# Altium schematic sheet Main_schematics.SchDoc
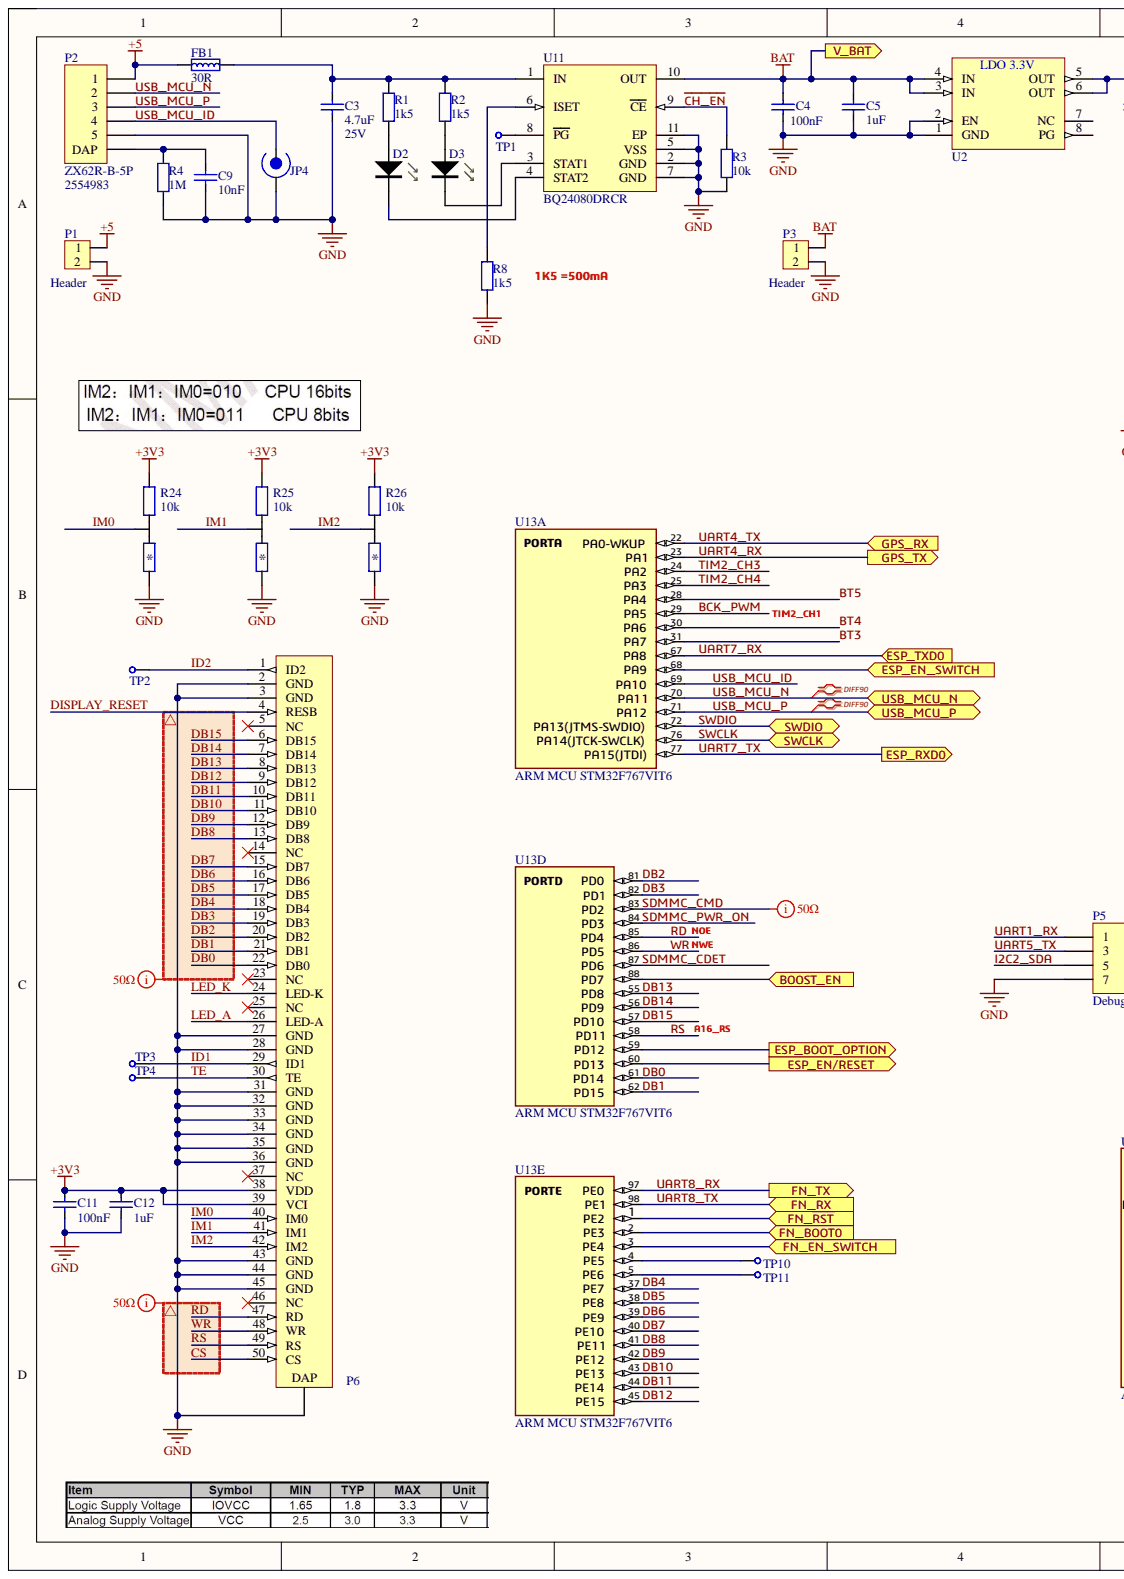
[diagram p0: Main_schematics.SchDoc, left]
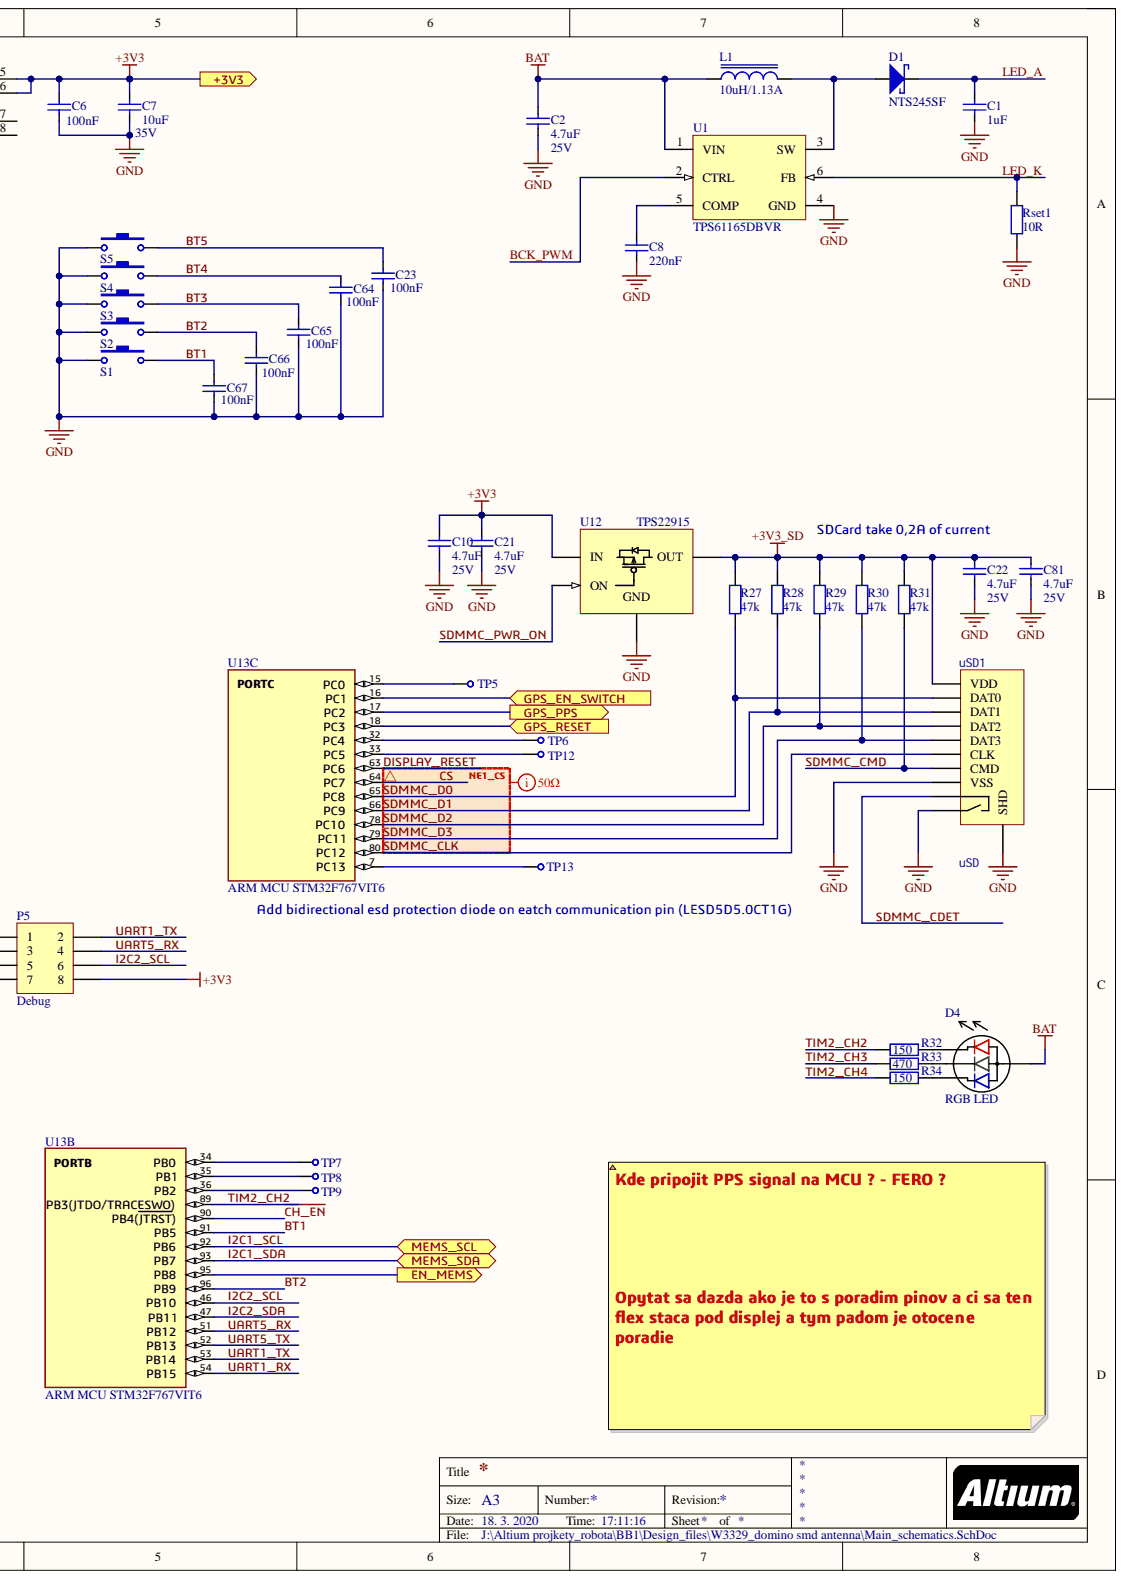
[diagram p1: Main_schematics.SchDoc, right]

## Parts
C1  1uF  [CAPACITOR_0603_(1608)]
C2  4.7uF  Voltage: 25V  [CAPACITOR_0603_(1608)]
C3  4.7uF  Voltage: 25V  [CAPACITOR_0603_(1608)]
C4  100nF  [CAPACITOR_0402_(1005)]
C5  1uF  [CAPACITOR_0603_(1608)]
C6  100nF  [CAPACITOR_0402_(1005)]
C7  10uF  Voltage Rating: 35V  [CAPACITOR_0805_(2012)]
C8  220nF  [CAPACITOR_0603_(1608)]
C9  10nF  [CAPACITOR_0603_(1608)]
C10  4.7uF  Voltage: 25V  [CAPACITOR_0603_(1608)]
C11  100nF  [CAPACITOR_0402_(1005)]
C12  1uF  [CAPACITOR_0603_(1608)]
C21  4.7uF  Voltage: 25V  [CAPACITOR_0603_(1608)]
C22  4.7uF  Voltage: 25V  [CAPACITOR_0603_(1608)]
C23  100nF  [CAPACITOR_0402_(1005)]
C64  100nF  [CAPACITOR_0402_(1005)]
C65  100nF  [CAPACITOR_0402_(1005)]
C66  100nF  [CAPACITOR_0402_(1005)]
C67  100nF  [CAPACITOR_0402_(1005)]
C81  4.7uF  Voltage: 25V  [CAPACITOR_0603_(1608)]
D1  NTS245SF  [SOD-123FL]
D2  LED GREEN  [LED_0805_GREEN]  (value not drawn)
D3  LED GREEN  [LED_0805_GREEN]  (value not drawn)
D4  RGB LED  [RGB SMD]
FB1  30R  [FERRITE BEAD_0603_(1608)]
JP4  Jumper  [JUMPER OFF SMD]  (value not drawn)  (no BOM)
L1  10uH/1.13A  [Power Inductor (SMD)]
P1  Header  [Header 2]
P2  ZX62R-B-5P  Supplier Part Number 1: 2554983  [ZX62R-B-5P]
P3  Header  [Header 2]
P5  Debug  [HDR2X4]
P6  Connector  [MOLEX 51338-0574]  (value not drawn)
R1  1k5  [RESISTOR_0603_(1608)]
R2  1k5  [RESISTOR_0603_(1608)]
R3  10k  [RESISTOR_0603_(1608)]
R4  1M  [RESISTOR_0603_(1608)]
R8  1k5  [RESISTOR_0603_(1608)]
R24  10k  [R603-3pad]
R25  10k  [R603-3pad]
R26  10k  [R603-3pad]
R27  47k  [RESISTOR_0603_(1608)]
R28  47k  [RESISTOR_0603_(1608)]
R29  47k  [RESISTOR_0603_(1608)]
R30  47k  [RESISTOR_0603_(1608)]
R31  47k  [RESISTOR_0603_(1608)]
R32  150  [RESISTOR_0603_(1608)]
R33  470  [RESISTOR_0603_(1608)]
R34  150  [RESISTOR_0603_(1608)]
Rset1  10R  [RESISTOR_0603_(1608)]
S1  DTSM-32S-B -  Tactile Switch  [DTSM-32S-B]  (value not drawn)
S2  DTSM-32S-B -  Tactile Switch  [DTSM-32S-B]  (value not drawn)
S3  DTSM-32S-B -  Tactile Switch  [DTSM-32S-B]  (value not drawn)
S4  DTSM-32S-B -  Tactile Switch  [DTSM-32S-B]  (value not drawn)
S5  DTSM-32S-B -  Tactile Switch  [DTSM-32S-B]  (value not drawn)
TP1  test point  [TP]  (value not drawn)  (no BOM)
TP2  test point  [TP]  (value not drawn)  (no BOM)
TP3  test point  [TP]  (value not drawn)  (no BOM)
TP4  test point  [TP]  (value not drawn)  (no BOM)
TP5  test point  [TP]  (value not drawn)  (no BOM)
TP6  test point  [TP]  (value not drawn)  (no BOM)
TP7  test point  [TP]  (value not drawn)  (no BOM)
TP8  test point  [TP]  (value not drawn)  (no BOM)
TP9  test point  [TP]  (value not drawn)  (no BOM)
TP10  test point  [TP]  (value not drawn)  (no BOM)
TP11  test point  [TP]  (value not drawn)  (no BOM)
TP12  test point  [TP]  (value not drawn)  (no BOM)
TP13  test point  [TP]  (value not drawn)  (no BOM)
U1  TPS61165DBVR  [TI-DBV6_L]
U2  LDO_3V3  [SOIC8]  (value not drawn)
U11  BQ24080DRCR  [DRC0010J_V]
U12  TPS22915  [DSBGA4_0.4mmpitch]
U13  ARM MCU STM32F767VIT6  Manufacturer Part Number: STM32F767VIT6  [STM-LQFP100_N]  (parts A,B,C,D,E)
uSD1  uSD  [uSD]

## Ports
+3V3, BOOST_EN, EN_MEMS, ESP_BOOT_OPTION, ESP_EN/RESET, ESP_EN_SWITCH, ESP_RXD0, ESP_TXD0, FN_BOOT0, FN_EN_SWITCH, FN_RX, FN_TX, FN_~{RST}, GPS_EN_SWITCH, GPS_PPS, GPS_RESET, GPS_RX, GPS_TX, MEMS_SCL, MEMS_SDA, SWCLK, SWDIO, USB_MCU_N, USB_MCU_P, V_BAT

## Nets
+3V3_SD: C22.1 C81.1 R27.2 R28.2 R29.2 R30.2 R31.2 U12.A1(OUT) uSD1.4(VDD)
+5: FB1.2 P1.1 P2.1
GND: C1.2 C2.2 C3.2 C4.2 C5.2 C6.2 C7.2 C8.1 C9.1 C10.2 C11.1 C12.1 C21.2 C22.2 C23.2 C64.2 C65.2 C66.2 C67.2 C81.2 JP4.1 P1.2 P2.5 P3.2 P5.7 P6.0 P6.2(GND) P6.3(GND) P6.27(GND) P6.28(GND) P6.31(GND) P6.32(GND) P6.33(GND) P6.34(GND) P6.35(GND) P6.36(GND) P6.43(GND) P6.44(GND) P6.45(GND) R3.1 R4.1 R8.1 R24.1 R25.1 R26.1 Rset1.1 S1.2 S2.2 S3.2 S4.2 S5.2 U1.4(GND) U2.1(GND) U2.2(EN) U11.2(GND) U11.5(VSS) U11.7(GND) U11.11(EP) U12.B1 uSD1.0(SHD) uSD1.6(VSS) uSD1.10
+3V3: C6.1 C7.1 C10.1 C11.2 C12.2 C21.1 P5.8 P6.38(VDD) P6.39(VCI) R24.3 R25.3 R26.3 U2.5(OUT) U2.6(OUT) U12.A2(IN) ; port +3V3
BAT: C2.1 C4.1 C5.1 D4.1 L1.1 P3.1 U1.1(VIN) U2.3(IN) U2.4(IN) U11.10(OUT) ; port V_BAT
BCK_PWM: U1.2(CTRL) U13.29(PA5)
BOOST_EN: U13.88(PD7) ; port BOOST_EN
BT1: C67.1 S1.1 U13.91(PB5)
BT2: C66.1 S2.1 U13.96(PB9)
BT3: C65.1 S3.1 U13.31(PA7)
BT4: C64.1 S4.1 U13.30(PA6)
BT5: C23.1 S5.1 U13.28(PA4)
~{CH_EN}: R3.2 U11.9(CE) U13.90(PB4(JTRST))
CS: P6.50(CS) U13.64(PC7)
DB0: P6.22(DB0) U13.61(PD14)
DB1: P6.21(DB1) U13.62(PD15)
DB2: P6.20(DB2) U13.81(PD0)
DB3: P6.19(DB3) U13.82(PD1)
DB4: P6.18(DB4) U13.37(PE7)
DB5: P6.17(DB5) U13.38(PE8)
DB6: P6.16(DB6) U13.39(PE9)
DB7: P6.15(DB7) U13.40(PE10)
DB8: P6.13(DB8) U13.41(PE11)
DB9: P6.12(DB9) U13.42(PE12)
DB10: P6.11(DB10) U13.43(PE13)
DB11: P6.10(DB11) U13.44(PE14)
DB12: P6.9(DB12) U13.45(PE15)
DB13: P6.8(DB13) U13.55(PD8)
DB14: P6.7(DB14) U13.56(PD9)
DB15: P6.6(DB15) U13.57(PD10)
DISPLAY_RESET: P6.4(RESB) U13.63(PC6)
EN_MEMS: U13.95(PB8) ; port EN_MEMS
ESP_BOOT_OPTION: U13.59(PD12) ; port ESP_BOOT_OPTION
ESP_EN/RESET: U13.60(PD13) ; port ESP_EN/RESET
ESP_EN_SWITCH: U13.68(PA9) ; port ESP_EN_SWITCH
FN_BOOT0: U13.2(PE3) ; port FN_BOOT0
FN_EN_SWITCH: U13.3(PE4) ; port FN_EN_SWITCH
FN_~{RST}: U13.1(PE2) ; port FN_~{RST}
GPS_EN_SWITCH: U13.16(PC1) ; port GPS_EN_SWITCH
GPS_PPS: U13.17(PC2) ; port GPS_PPS
GPS_RESET: U13.18(PC3) ; port GPS_RESET
I2C1_SCL: U13.92(PB6) ; port MEMS_SCL
I2C1_SDA: U13.93(PB7) ; port MEMS_SDA
I2C2_SCL: P5.6 U13.46(PB10)
I2C2_SDA: P5.5 U13.47(PB11)
ID1: P6.29(ID1) TP3.1
ID2: P6.1(ID2) TP2.1
IM0: P6.40(IM0) R24.2
IM1: P6.41(IM1) R25.2
IM2: P6.42(IM2) R26.2
LED_A: C1.1 D1.2 P6.26(LED-A)
LED_K: P6.24(LED-K) Rset1.2 U1.6(FB)
RD: P6.47(RD) U13.85(PD4)
RS: P6.49(RS) U13.58(PD11)
SDMMC_CDET: U13.87(PD6) uSD1.9
SDMMC_CLK: U13.80(PC12) uSD1.5(CLK)
SDMMC_CMD: R31.1 U13.83(PD2) uSD1.3(CMD)
SDMMC_D0: R27.1 U13.65(PC8) uSD1.7(DAT0)
SDMMC_D1: R28.1 U13.66(PC9) uSD1.8(DAT1)
SDMMC_D2: R29.1 U13.78(PC10) uSD1.1(DAT2)
SDMMC_D3: R30.1 U13.79(PC11) uSD1.2(DAT3)
SDMMC_PWR_ON: U12.B2(ON) U13.84(PD3)
SWCLK: U13.76(PA14(JTCK-SWCLK)) ; port SWCLK
SWDIO: U13.72(PA13(JTMS-SWDIO)) ; port SWDIO
TE: P6.30(TE) TP4.1
TIM2_CH2: R32.2 U13.89(PB3(JTDO/TRACESWO))
TIM2_CH3: R33.2 U13.24(PA2)
TIM2_CH4: R34.2 U13.25(PA3)
UART1_RX: P5.1 U13.54(PB15)
UART1_TX: P5.2 U13.53(PB14)
UART4_RX: U13.23(PA1) ; port GPS_TX
UART4_TX: U13.22(PA0-WKUP) ; port GPS_RX
UART5_RX: P5.4 U13.51(PB12)
UART5_TX: P5.3 U13.52(PB13)
UART7_RX: U13.67(PA8) ; port ESP_TXD0
UART7_TX: U13.77(PA15(JTDI)) ; port ESP_RXD0
UART8_RX: U13.97(PE0) ; port FN_TX
UART8_TX: U13.98(PE1) ; port FN_RX
USB_MCU_ID: JP4.2 P2.4 U13.69(PA10)
USB_MCU_N: P2.2 U13.70(PA11) ; port USB_MCU_N
USB_MCU_P: P2.3 U13.71(PA12) ; port USB_MCU_P
WR: P6.48(WR) U13.86(PD5)
NetC3_1: C3.1 FB1.1 R1.2 R2.2 U11.1(IN)
NetC8_2: C8.2 U1.5(COMP)
NetC9_2: C9.2 P2.0(DAP) R4.2
NetD1_1: D1.1 L1.2 U1.3(SW)
NetD2_A: D2.A R1.1
NetD2_K: D2.K U11.4(STAT2)
NetD3_A: D3.A R2.1
NetD3_K: D3.K U11.3(STAT1)
NetD4_2: D4.2 R34.1
NetD4_3: D4.3 R32.1
NetD4_4: D4.4 R33.1
NetR8_2: R8.2 U11.6(ISET)
NetTP1_1: TP1.1 U11.8(PG)
NetTP5_1: TP5.1 U13.15(PC0)
NetTP6_1: TP6.1 U13.32(PC4)
NetTP7_1: TP7.1 U13.34(PB0)
NetTP8_1: TP8.1 U13.35(PB1)
NetTP9_1: TP9.1 U13.36(PB2)
NetTP10_1: TP10.1 U13.4(PE5)
NetTP11_1: TP11.1 U13.5(PE6)
NetTP12_1: TP12.1 U13.33(PC5)
NetTP13_1: TP13.1 U13.7(PC13)

Not connected: P6 5(NC) 14(NC) 23(NC) 25(NC) 37(NC) 46(NC); U2 7(NC) 8(PG)

## Library data of the parts
C1 C4-C6 C8 C9 C11 C12 C23 C64-C67 = CAPACITOR: ESR: 0.28R; Voltage Rating: 10V; Component Kind: Standard; Description: CAPACITOR; Library Name: RLCFB.SchLib
  C1 C5 C12: Capacitance: 1uF
  C4 C6 C11 C23 C64-C67: Capacitance: 100nF
  C8: Capacitance: 220nF
  C9: Capacitance: 10nF
C2 C3 C10 C21 C22 C81 = CAPACITOR: Manufacturer 1: Murata; Manufacturer Part Number 1: GRM188R61E475KE11D; Dielectric: X5R; ESR: 0.28R; Material: Ceramic; Tolerance: 10%; Capacitance: 4.7uF; Case Code (Imperial): 0603; Case Code (Metric): 1608; Case/Package: 0603; Component Kind: Standard; ComponentLink1Description: https://datasheet.ciiva.com/46444/1769893-46444982.pdf?src-supplier=Element14; ComponentLink1URL: https://datasheet.ciiva.com/46444/1769893-46444982.pdf?src-supplier=Element14; ComponentLink2Description: https://datasheet.ciiva.com/46444/1769893-46444983.pdf?src-supplier=Element14; ComponentLink2URL: https://datasheet.ciiva.com/46444/1769893-46444983.pdf?src-supplier=Element14; ComponentLink3Description: https://datasheet.ciiva.com/14891/partnumbering-e-01-14891102.pdf?src-supplier=Digi-Key; ComponentLink3URL: https://datasheet.ciiva.com/14891/partnumbering-e-01-14891102.pdf?src-supplier=Digi-Key; ComponentLink4Description: https://datasheet.ciiva.com/14891/partnumbering-e-01-14891103.pdf?src-supplier=Digi-Key; ComponentLink4URL: https://datasheet.ciiva.com/14891/partnumbering-e-01-14891103.pdf?src-supplier=Digi-Key; Depth: 0.8mm; Description: CAPACITOR; Height: 0.8mm; Lead Free: Lead Free; Length: 1.6mm; Max Operating Temperature: 85°C; Min Operating Temperature: -55°C; Mount: Surface Mount; Package Quantity: 4000; Packaging: Cut Tape; Pricing 1: 10=0,35700, 100=100,00000, 500=0,15900, 1000=0,12800, 2000=0,10200 (CHF); REACH SVHC: No SVHC; RoHS: Yes; Stock 1: 95119; Supplier 1: Farnell; Supplier Part Number 1: 2426959; Thickness: 0.031inch; Voltage Rating: 25V; Voltage Rating (DC): 25V; Width: 0.031inch; Library Name: RLCFB.SchLib
C7 = CAPACITOR: Manufacturer 1: Murata; Manufacturer Part Number 1: GRM21BR6YA106KE43L; Dielectric: X5R; Tolerance: 10%; Capacitance: 10uF; Case Code (Imperial): 0805; Case Code (Metric): 2012; Case/Package: 0805; Component Kind: Standard; ComponentLink1Description: http://www.farnell.com/datasheets/2079187.pdf?src-supplier=Farnell; ComponentLink1URL: http://www.farnell.com/datasheets/2079187.pdf?src-supplier=Farnell; ComponentLink2Description: http://www.mouser.com/ds/2/281/c02e-2905.pdf?src-supplier=Mouser; ComponentLink2URL: http://www.mouser.com/ds/2/281/c02e-2905.pdf?src-supplier=Mouser; ComponentLink3Description: http://search.murata.co.jp/Ceramy/image/img/A01X/G101/ENG/GRM21BR6YA106KE43-01.pdf?src-supplier=Digi-Key; ComponentLink3URL: http://search.murata.co.jp/Ceramy/image/img/A01X/G101/ENG/GRM21BR6YA106KE43-01.pdf?src-supplier=Digi-Key; ComponentLink4Description: http://search.murata.co.jp/Ceramy/image/img/A01X/partnumbering_e_01.pdf?src-supplier=Digi-Key; ComponentLink4URL: http://search.murata.co.jp/Ceramy/image/img/A01X/partnumbering_e_01.pdf?src-supplier=Digi-Key; ComponentLink5Description: http://www.farnell.com/datasheets/2079187.pdf?src-supplier=Newark; ComponentLink5URL: http://www.farnell.com/datasheets/2079187.pdf?src-supplier=Newark; ComponentLink6Description: http://www.farnell.com/datasheets/2079187.pdf?src-supplier=Element14; ComponentLink6URL: http://www.farnell.com/datasheets/2079187.pdf?src-supplier=Element14; Description: CAPACITOR; Height: 1.25mm; Lead Free: Lead Free; Length: 0.079inch; Max Operating Temperature: 85°C; Min Operating Temperature: -55°C; Mount: Surface Mount; Package Quantity: 3000; Packaging: Tape and Reel; Pricing 1: 5=0,38700, 50=0,21000, 250=0,18000, 500=0,11500, 1500=0,10500, 3000=0,09410, 15000=0,09070 (EUR); REACH SVHC: No SVHC; RoHS: Yes; Stock 1: 109244; Supplier 1: Farnell; Supplier Part Number 1: 2611943; Termination: SMD/SMT; Thickness: 0.057inch; Voltage Rating (DC): 35V; Weight: 0.000335oz; Width: 0.049inch; Library Name: RLCFB.SchLib
D1 = D_NTS245SF: Very Low Forward Voltage  Trench-based Schottky  Rectifier in SOD−123FL; Manufacturer: ON Semiconductor; Manufacturer Part Number: NTS245SFT1G; Average Rectified Current: 2A; Element Configuration: Single; Forward Voltage: 630mV; Max Forward Surge Current (Ifsm): 50A; Max Repetitive Reverse Voltage (Vrrm): 45V; Peak Reverse Current: 90uA; Case/Package: SOD; Component Kind: Standard; ComponentLink1Description: http://www.mouser.com/ds/2/308/NTS245SF-D-532018.pdf; ComponentLink1URL: http://www.mouser.com/ds/2/308/NTS245SF-D-532018.pdf; ComponentLink2Description: http://uk.rs-online.com/webdocs/13b8/0900766b813b8bbc.pdf; ComponentLink2URL: http://uk.rs-online.com/webdocs/13b8/0900766b813b8bbc.pdf; ComponentLink3Description: http://www.farnell.com/datasheets/1900863.pdf; ComponentLink3URL: http://www.farnell.com/datasheets/1900863.pdf; ComponentLink4Description: http://www.onsemi.com/pub/Collateral/NTS245SF-D.PDF; ComponentLink4URL: http://www.onsemi.com/pub/Collateral/NTS245SF-D.PDF; ComponentLink5Description: http://www.onsemi.com/pub_link/Collateral/NTS245SF-D.PDF; ComponentLink5URL: http://www.onsemi.com/pub_link/Collateral/NTS245SF-D.PDF; Forward Current: 2A; Height: 0.88mm; Lead Free: Lead Free; Length: 2.9mm; Max Operating Temperature: 150°C; Max Reverse Voltage (DC): 45V; Min Operating Temperature: -65°C; Mount: Surface Mount; Number of Pins: 2; Package Quantity: 3000; Packaging: Tape and Reel; Pricing 1: 5=0.276, 25=0.267, 100=0.108, 250=0.101, 500=0.0772, 1000=0.0533, 5000=0.0433 (EUR); REACH SVHC: No SVHC; Reverse Voltage (DC): 45V; Stock 1: 46422; Supplier 1: Farnell; Supplier Part Number 1: 2473418; Width: 1.8mm; Library Name: LVDS_to_LVTTL.SchLib
D2 D3 = LED GREEN 0805: KP-2012LSGC -  LED, Low Power, Green, SMD, 0805, 2 mA, 1.9 V, 568 nm; Manufacturer 1: Kingbright; Forward Current: 2mA; Forward Voltage: 1.9V; Lens Style: Rectangular; Viewing Angle: 120°; Wavelength: 568nm; Case/Package: 120; Color: Green; Component Kind: Standard; ComponentLink1Description: http://www.farnell.com/datasheets/1854080.pdf?src-supplier=Farnell; ComponentLink1URL: http://www.farnell.com/datasheets/1854080.pdf?src-supplier=Farnell; ComponentLink2Description: http://www.farnell.com/datasheets/1854080.pdf?src-supplier=Element14; ComponentLink2URL: http://www.farnell.com/datasheets/1854080.pdf?src-supplier=Element14; Library Name: Source.SchLib; Manufacturer Part Number 1: KP-2012LSGC; Packaging: Cut Tape; Pricing 1: 5=0,11200, 10=0,10000, 25=0,08820, 50=0,08670, 100=0,08510, 150=0,08330, 200=0,08150, 250=0,07970 (EUR); REACH SVHC: No SVHC; RoHS: Yes; Stock 1: 19790; Supplier 1: Farnell; Supplier Part Number 1: 2463991
D4 = RGB LED: RGB LED kolmo na PCB; Component Kind: Standard; Library Name: BB1_SCH_Library.SchLib; Supplier 1: Farnell; Supplier Part Number 1: 2322115
FB1 = FERRITE_BEAD: DC Current Rating: 400mA; DC Resistance Max: 100R; Component Kind: Standard; Description: FERRITE_BEAD; Impedance@100MHz: 30R; Library Name: RLCFB.SchLib
JP4 = Jumper SMD: Description: Jumper; Library Name: Source.SchLib
L1 = IND_VLCF5020T: VLCF5020T-100M1R1-1 -  Power Inductor (SMD), 10 µH, 1.5 A, Wirewound, 1.13 A, VLCF Series, 5.3mm x 5mm x 2mm; Manufacturer: TDK; Core Material: Ferrite; Current Rating: 1.13A; DC Resistance (DCR): 237mR; Inductance: 10uh; Inductance Tolerance: 20%; RMS Current (Irms): 1.5A; Shielding: Shielded; Test Frequency: 100kHz; Case/Package: 2020; Component Kind: Standard; Composition: Wirewound; DC Current: 1.13A; Depth: 5.2mm; Height: 2mm; Height - Seated (Max): 0.079inch; Lead Free: Lead Free; Length: 0.197inch; Manufacturer Part Number: VLCF5020T-100M1R1-1; Max DC Current: 1.13A; Max Operating Temperature: 105°C; Military Standard: Not; Min Operating Temperature: -40°C; Mount: Surface Mount; Number of Pins: 2; Package Quantity: 500; Packaging: Tape and Reel; Pricing 1: 1=1.15, 10=0.755, 50=0.57, 100=0.51, 200=0.46, 500=0.409, 2500=0.359 (EUR); Radiation Hardening: No; REACH SVHC: No SVHC; Saturation Current: 1.13A; Stock 1: 1025; Supplier 1: Farnell; Supplier Part Number 1: 1670091; Termination: SMD/SMT; Tolerance: 20%; Width: 0.197inch; Library Name: LVDS_to_LVTTL.SchLib
P1 P3 = Header 2: 2.54mm header 2x1; Component Kind: Standard; Library Name: LVDS_to_LVTTL.SchLib
P2 = ZX62R-B-5P: micro USB reverse; Component Kind: Standard; Library Name: BB1_SCH_Library.SchLib; Supplier 1: Farnell
P5 = Header 4X2: Header, 4-Pin, Dual row; Component Kind: Standard; LatestRevisionDate: 17-Jul-2002; LatestRevisionNote: Re-released for DXP Platform.; Library Name: Miscellaneous Connectors.IntLib; Signal Integrity: Connector; Publisher: Altium Limited
P6 = Molex 0559090574: MOLEX         51338-0574            Stacking Board Connector, Receptacle, 0.4 mm, 50 Contacts, Surface Mount, Gold Plated Contacts; Current Rating: 300mA; Glow Wire Compliant: Non-compliant; Insulation Resistance: 100MR; Number of Circuits: 50; Pitch: 0.4mm; Voltage Rating (AC): 50V; Category: Headers and Wire Housings; Color: Black; Component Kind: Standard; ComponentLink1Description: https://datasheet.ciiva.com/1440/1887871-1440036.pdf?src-supplier=Element14; ComponentLink1URL: https://datasheet.ciiva.com/1440/1887871-1440036.pdf?src-supplier=Element14; Contact Material: Bronze; Contact Plating: Gold; ELV: Compliant; Flammability Rating: UL94 V-0; Gender: Receptacle; Halogen Free: Low Halogen; Housing Material: Thermoplastic; Library Name: Display converter.SchLib; Manufacturer 1: Molex; Manufacturer Part Number 1: 51338-0574; Material: Bronze; Mount: Surface Mount; Number of Contacts: 50; Number of Positions: 50; Number of Rows: 2; Orientation: Vertical; Package Quantity: 2500; Packaging: Tape and Reel; Pricing 1: 1=2,06000, 10=1,87200, 25=1,75760, 50=1,68120, 100=1,60480, 250=1,45192, 500=1,33730, 1000=1,14626 (USD); REACH SVHC: Unknown; RoHS: Yes; Stock 1: 7419; Supplier 1: Digi-Key; Supplier Part Number 1: WM3354CT-ND; Termination: SMD/SMT; Voltage Rating (DC): 50V
R1-R4 R8 R27-R34 Rset1 = RESISTOR: Power Rating: 500mW; Component Kind: Standard; Description: RESISTOR; Library Name: RLCFB.SchLib
  R1 R2 R8: Resistance: 1k5
  R3: Resistance: 10k
  R4: Resistance: 1M
  R27-R31: Resistance: 47k
  R32 R34: Resistance: 150
  R33: Resistance: 470
  Rset1: Resistance: 10R
R24-R26 = R_PULL: Pull up or pull down resistor; Component Kind: Standard; Library Name: BB1_SCH_Library.SchLib
S1-S5 = Switch tactile: DTSM-32S-B -  Tactile Switch, DTSM-3 Series, Top Actuated, Surface Mount, Rectangular Button, 320 gf; Manufacturer 1: Multicomp; Contact Resistance: 100mR; Current Rating: 50mA; Illumination Type: Illuminated; Operating Force: 3.14N; Throw Configuration: SPST; Voltage Rating (DC): 12V; Case/Package: SMD/SMT; Component Kind: Standard; ComponentLink1Description: https://datasheet.ciiva.com/1532/44707-1532733.pdf?src-supplier=Element14; ComponentLink1URL: https://datasheet.ciiva.com/1532/44707-1532733.pdf?src-supplier=Element14; ComponentLink2Description: https://datasheet.ciiva.com/1532/10424-1532734.pdf?src-supplier=Element14; ComponentLink2URL: https://datasheet.ciiva.com/1532/10424-1532734.pdf?src-supplier=Element14; ComponentLink3Description: https://datasheet.ciiva.com/22631/1662013-22631920.pdf?src-supplier=Farnell; ComponentLink3URL: https://datasheet.ciiva.com/22631/1662013-22631920.pdf?src-supplier=Farnell; Height: 5mm; Insulation Resistance: 100MR; Length: 6mm; Library Name: BB1_SCH_Library.SchLib; Manufacturer Part Number 1: DTSM-32S-B; Max Operating Temperature: 70°C; Min Operating Temperature: -25°C; Pricing 1: 15=0,18700, 150=0,15100, 500=0,10800, 1000=0,09630, 1500=0,08590, 5000=0,07220 (EUR); REACH SVHC: No SVHC; RoHS: Yes; Stock 1: 170226; Supplier 1: Farnell; Supplier Part Number 1: 9471898; Weight: 0.01lb; Width: 6mm
U1 = TPS61165DBVR: High Brightness White LED Driver, 3 to 18 V, -40 to 85 degC, 6-pin SOT23 (DBV6), Green (RoHS & no Sb/Br); Manufacturer: Texas Instruments; Dimming: TRUE; Iq(Typ)(mA): 1.5; Rating: Catalog; Switching Frequency(Max)(kHz): 1200; Topology: Boost; Vin(Max)(V): 18; Vin(Min)(V): 3; Code_JEDEC: MO-178; Component Kind: Standard; ComponentLink1Description: Manufacturer URL; ComponentLink1URL: http://www.ti.com/; ComponentLink2Description: Datasheet; ComponentLink2URL: http://www.ti.com/general/docs/lit/getliterature.tsp?genericPartNumber=TPS61165&fileType=pdf; ComponentLink3Description: Package Specification; ComponentLink3URL: http://www.ti.com/litv/pdf/mpds026h; DatasheetVersion: SLVS790B; Eco-Plan: Green (RoHS & no Sb/Br); Mounting Technology: Surface Mount; PackageDescription: 6-Pin Plastic Small Outline, Body 1.60 Ã— 2.90 mm, Pitch 0.95 mm; PackageReference: DBV6; PackageVersion: 4073253-5/K; PartNumber: TPS61165DBVR; SubFamily: White LED Driver; Supplier 6: Farnell; Supplier Part Number 6: 2764825; Synchronous Rectification: TRUE; Library Name: LVDS_to_LVTTL.SchLib
U2 = LDO_3V3: FAST TRANSIENT RESPONSE, 1-A LOW-DROPOUT VOLTAGE REGULATOR; Manufacturer: TEXAS INSTRUMENTS; Manufacturer Part Number: TPS76833QD; Component Kind: Standard; ComponentLink1Description: http://www.ti.com/lit/ds/symlink/tps76801.pdf; ComponentLink1URL: http://www.ti.com/lit/ds/symlink/tps76801.pdf; ComponentLink2Description: http://www.farnell.com/cad/1610971.zip; ComponentLink2URL: http://www.farnell.com/cad/1610971.zip; Pricing 1: 1=2,17, 10=1,9, 100=1,31 (EUR); RoHS: YES; Stock 1: 381; Supplier 1: Farnell; Supplier Part Number 1: 8461481; Library Name: LVDS_to_LVTTL.SchLib
U11 = BQ24080DRCR: 1-Cell Li-Ion Charger with 1 A FET, AC Present and Charge Enable, 4.5 V, -40 to 85 degC, 10-pin SON (DRC), Green (RoHS & no Sb/Br); Manufacturer 1: Texas Instruments; Max Charge Current: 1A; Max Output Current: 1.5A; Max Output Voltage: 4.2V; Max Power Dissipation: 1.5W; Max Supply Voltage: 6.5V; Min Input Voltage: 4.5V; Number of Cells: 1; Component Kind: Standard; ComponentLink1Description: https://datasheet.ciiva.com/4873/slus698e-128296-4873536.pdf?src-supplier=Mouser; ComponentLink1URL: https://datasheet.ciiva.com/4873/slus698e-128296-4873536.pdf?src-supplier=Mouser; ComponentLink2Description: https://datasheet.ciiva.com/1136/1768090-1136584.pdf?src-supplier=Element14; ComponentLink2URL: https://datasheet.ciiva.com/1136/1768090-1136584.pdf?src-supplier=Element14; ComponentLink3Description: https://datasheet.ciiva.com/15114/getdatasheetpartid-188154-15114504.pdf?src-supplier=Verical; ComponentLink3URL: https://datasheet.ciiva.com/15114/getdatasheetpartid-188154-15114504.pdf?src-supplier=Verical; ComponentLink4Description: https://datasheet.ciiva.com/1932/bq24081-1932212.pdf?src-supplier=Digi-Key; ComponentLink4URL: https://datasheet.ciiva.com/1932/bq24081-1932212.pdf?src-supplier=Digi-Key; ComponentLink5Description: https://datasheet.ciiva.com/1932/bq24081-1932213.pdf?src-supplier=Digi-Key; ComponentLink5URL: https://datasheet.ciiva.com/1932/bq24081-1932213.pdf?src-supplier=Digi-Key; ComponentLink6Description: https://datasheet.ciiva.com/1932/bq24081-1932214.pdf?src-supplier=Digi-Key; ComponentLink6URL: https://datasheet.ciiva.com/1932/bq24081-1932214.pdf?src-supplier=Digi-Key; ComponentLink7Description: https://datasheet.ciiva.com/3214/bq24080-3214054.pdf?src-supplier=Avnet; ComponentLink7URL: https://datasheet.ciiva.com/3214/bq24080-3214054.pdf?src-supplier=Avnet; Contact Plating: Gold; Lead Free: Lead Free; Library Name: BB1_SCH_Library.SchLib; Manufacturer Part Number 1: BQ24080DRCR; Max Operating Temperature: 125°C; Min Operating Temperature: -40°C; Min Supply Voltage: 4.5V; Number of Outputs: 1; Number of Pins: 10; Output Current: 1A; Output Voltage: 4.2V; Package Quantity: 1; Packaging: Tape and Reel; Pricing 1: 1=1,82000, 10=1,54000, 100=1,24000, 500=1,08000, 1000=0,89400, 3000=0,83200, 6000=0,80100, 9000=0,77000 (USD); Radiation Hardening: No; REACH SVHC: No SVHC; RoHS: Yes; Stock 1: 3814; Supplier 1: Mouser; Supplier Part Number 1: 595-BQ24080DRCR; Termination: SMD/SMT; Weight: 0.000921oz
U12 = TPS22915: TPS22915  Power Switch ICs - Power Distribution 5.5V, 2A, 38mOhm Load Switch   tR=64 µs  QUICK OUTPUT DISCHARGE=Yes; Manufacturer 1: Texas Instruments; Manufacturer Part Number 1: TPS22915BYFPR; Interface: On/Off; Number of Channels: 1; Output Configuration: High Side; Quiescent Current: 7.7uA; Component Kind: Standard; ComponentLink1Description: http://www.ti.com/lit/ds/symlink/tps22915.pdf?src-supplier=Digi-Key; ComponentLink1URL: http://www.ti.com/lit/ds/symlink/tps22915.pdf?src-supplier=Digi-Key; ComponentLink2Description: http://www.ti.com/general/docs/lit/getliterature.tsp?genericPartNumber=TPS22915&&fileType=pdf&src-supplier=Avnet; ComponentLink2URL: http://www.ti.com/general/docs/lit/getliterature.tsp?genericPartNumber=TPS22915&&fileType=pdf&src-supplier=Avnet; ComponentLink3Description: http://www.ti.com/lit/gpn/tps22915?src-supplier=Mouser; ComponentLink3URL: http://www.ti.com/lit/gpn/tps22915?src-supplier=Mouser; Lead Free: Lead Free; Library Name: BB1_SCH_Library.SchLib; Max Operating Temperature: 85°C; Max Output Current: 2A; Min Operating Temperature: -40°C; Mount: Surface Mount; Number of Outputs: 1; Number of Pins: 4; Packaging: Tape and Reel; Pricing 1: 1=0,42000, 10=0,35000, 100=0,21300, 1000=0,16400, 3000=0,14000, 9000=0,13000 (USD); RoHS: Yes; Stock 1: 3444; Supplier 1: Mouser; Supplier Part Number 1: 595-TPS22915BYFPR
U13 = STM32F767VIT6_LQFP100: STM32F767VIT6 -  ARM MCU, ART Accelerator, STM32 Family STM32F7 Series Microcontrollers, ARM Cortex-M7, 32bit; Manufacturer: STMicroelectronics; Frequency: 216MHz; Max Supply Voltage: 3.6V; Memory Size: 2MB; Min Supply Voltage: 1.7V; Number of I/Os: 82; RAM Size: 512KB; Case/Package: LQFP; Component Kind: Standard; ComponentLink1Description: http://farnell.com//datasheets/2282439.pdf?src-supplier=Farnell; ComponentLink1URL: http://farnell.com//datasheets/2282439.pdf?src-supplier=Farnell; ComponentLink2Description: http://www.farnell.com/datasheets/2034586.pdf?src-supplier=Element14; ComponentLink2URL: http://www.farnell.com/datasheets/2034586.pdf?src-supplier=Element14; ComponentLink3Description: http://www.st.com/content/ccc/resource/technical/document/datasheet/group3/c5/37/9c/1d/a6/09/4e/1a/DM00273119/files/DM00273119.pdf/jcr:content/translations/en.DM00273119.pdf?src-supplier=Digi-Key; ComponentLink3URL: http://www.st.com/content/ccc/resource/technical/document/datasheet/group3/c5/37/9c/1d/a6/09/4e/1a/DM00273119/files/DM00273119.pdf/jcr:content/translations/en.DM00273119.pdf?src-supplier=Digi-Key; Library Name: BB1_SCH_Library.SchLib; Number of Pins: 100; Pricing 1: 1=14,27000, 10=12,66000, 25=12,13000, 50=11,15000, 100=10,17000 (EUR); REACH SVHC: No SVHC; RoHS: No; Stock 1: 313; Supplier 1: Farnell; Supplier Part Number 1: 2535500
uSD1 = uSD: MICRO SD; Manufacturer 1: Hirose; Manufacturer Part Number 1: DM3D-SF; Connector Type: Connector,Socket; Contact Resistance: 100mR; Current Rating: 500mA; Gender: Female; Insulation Resistance: 1GR; Max Voltage Rating (AC): 125V; Number of Contacts: 8; Pitch: 1.1mm; Component Kind: Standard; ComponentLink1Description: https://datasheet.ciiva.com/11755/0900766b80fd13c1-11755152.pdf?src-supplier=RSComponents; ComponentLink1URL: https://datasheet.ciiva.com/11755/0900766b80fd13c1-11755152.pdf?src-supplier=RSComponents; ComponentLink2Description: https://datasheet.ciiva.com/1105/1697167-1105105.pdf?src-supplier=Element14; ComponentLink2URL: https://datasheet.ciiva.com/1105/1697167-1105105.pdf?src-supplier=Element14; ComponentLink3Description: https://datasheet.ciiva.com/683/e60900232-683362.pdf?src-supplier=Digi-Key; ComponentLink3URL: https://datasheet.ciiva.com/683/e60900232-683362.pdf?src-supplier=Digi-Key; ComponentLink4Description: https://datasheet.ciiva.com/683/e60900232-683363.pdf?src-supplier=Digi-Key; ComponentLink4URL: https://datasheet.ciiva.com/683/e60900232-683363.pdf?src-supplier=Digi-Key; ComponentLink5Description: https://datasheet.ciiva.com/683/e60900232-683364.pdf?src-supplier=Digi-Key; ComponentLink5URL: https://datasheet.ciiva.com/683/e60900232-683364.pdf?src-supplier=Digi-Key; ComponentLink6Description: https://datasheet.ciiva.com/15174/getdatasheetpartid-432464-15174822.pdf?src-supplier=Verical; ComponentLink6URL: https://datasheet.ciiva.com/15174/getdatasheetpartid-432464-15174822.pdf?src-supplier=Verical; ComponentLink7Description: https://datasheet.ciiva.com/22627/1697167-22627974.pdf?src-supplier=Farnell; ComponentLink7URL: https://datasheet.ciiva.com/22627/1697167-22627974.pdf?src-supplier=Farnell; ComponentLink8Description: https://datasheet.ciiva.com/3122/e60900232-3122537.pdf?src-supplier=Avnet; ComponentLink8URL: https://datasheet.ciiva.com/3122/e60900232-3122537.pdf?src-supplier=Avnet; ComponentLink9Description: https://datasheet.ciiva.com/16287/e60900232-16287615.pdf?src-supplier=Future+Electronics; ComponentLink9URL: https://datasheet.ciiva.com/16287/e60900232-16287615.pdf?src-supplier=Future+Electronics; Contact Material: Copper Alloy,Copper; Contact Plating: Gold; Depth: 11.45mm; Flammability Rating: UL94 V-0; Housing Material: Polymer; Lead Free: Lead Free; Length: 11.95mm; Library Name: BB1_SCH_Library.SchLib; Max Current Rating: 500mA; Max Operating Temperature: 85°C; Min Operating Temperature: -25°C; Mount: Surface Mount; Number of Rows: 1; Orientation: Right Angle; Package Quantity: 1; Packaging: Tape and Reel; Pricing 1: 1=1,33000, 50=1,25000, 100=1,22000, 250=1,11000, 500=1,02000, 1000=0,76700 (EUR); Radiation Hardening: No; REACH SVHC: No SVHC; RoHS: Yes; Stock 1: 1209; Supplier 1: Farnell; Supplier Part Number 1: 1764377; Termination: Solder; Voltage Rating (AC): 125V; Width: 11.95mm
TP1-TP13 = test point: Library Name: BB1_SCH_Library.SchLib
